# Altium schematic sheet I_PRV_UWS_B2_1_0_PSU.SchDoc
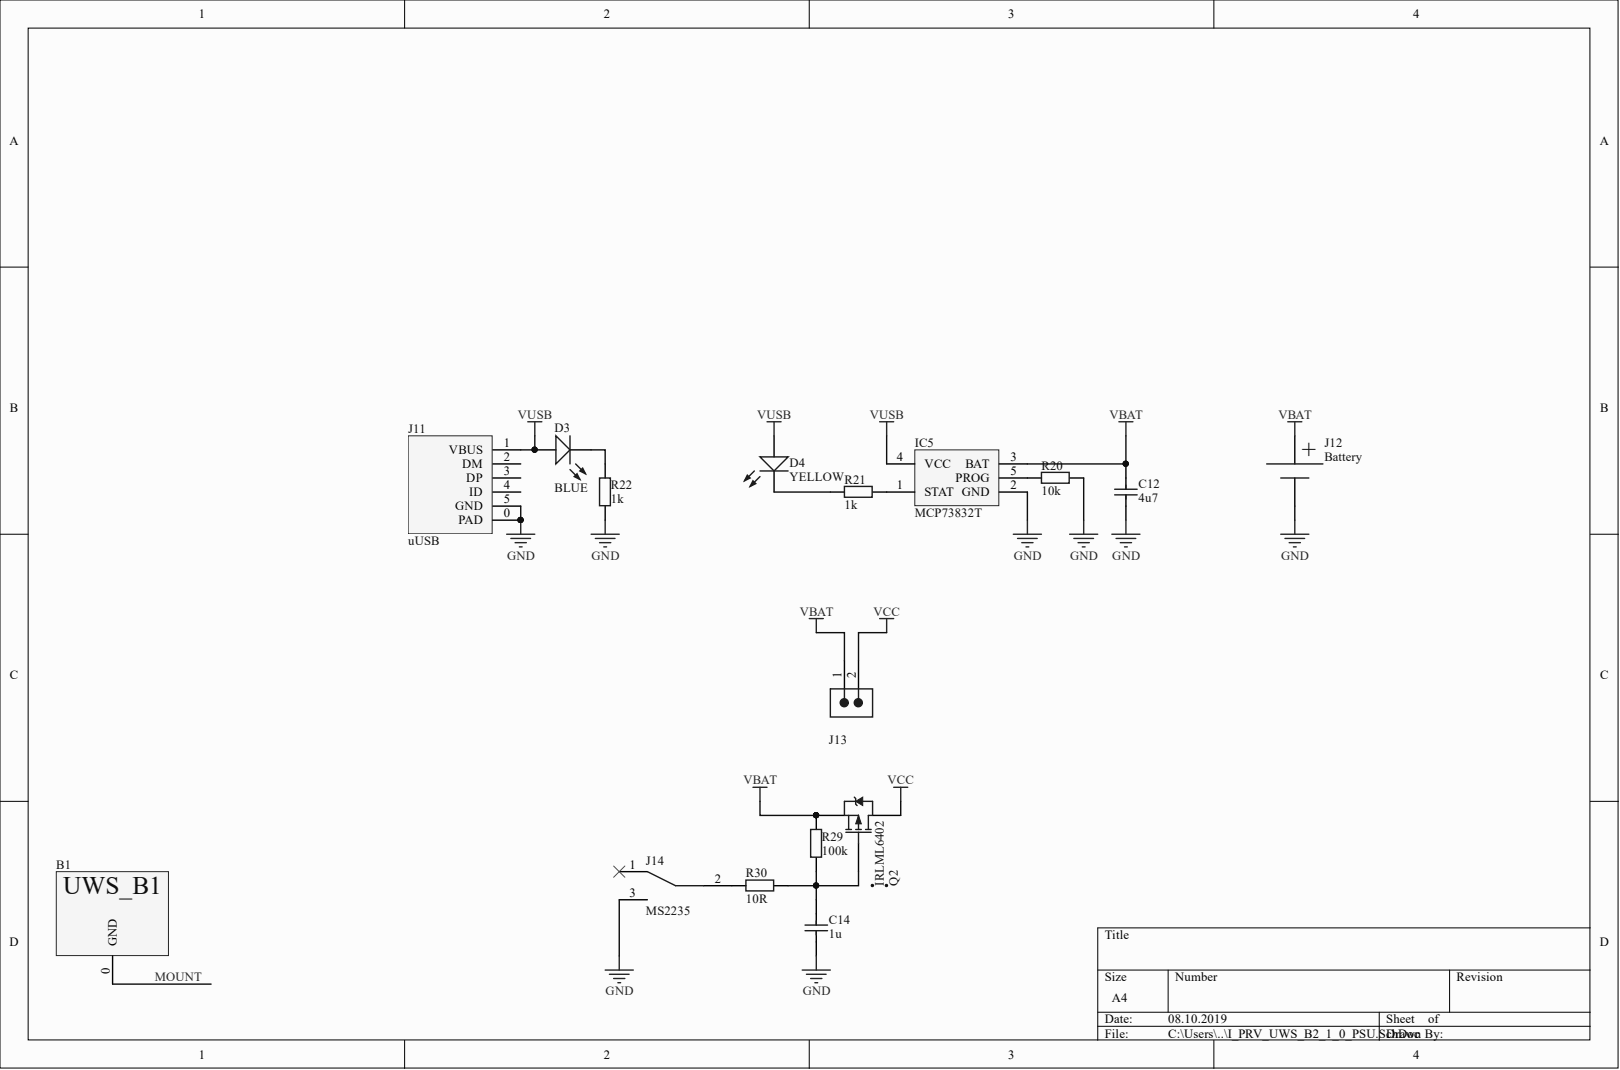
[diagram p0: I_PRV_UWS_B2_1_0_PSU.SchDoc]

## Parts
B1  UWS_B1_outline  [UWS_B1_outline]  (value not drawn)
C12  value 4u7  [SMD0805_CAP]  (hidden comment: Capacitor)
C14  value 1u  [SMD0603_CAP]  (hidden comment: Capacitor)
D3  value BLUE  [SMD0603_LED]  (hidden comment: LED)
D4  value YELLOW  [SMD0603_LED]  (hidden comment: LED)
IC5  MCP73832T  [SOT23-5]
J11  uUSB  [microUSB]
J12  Battery  [LiIon_UWS]
J13  Pinhead 2pin 2.54mm  [Pinhead 2pi 2.54mm]  (value not drawn)
J14  MS2235  [MSS-2235S]
Q2  IRLML6402  [SOT_23]
R20  value 10k  [SMD0402_RES]  (hidden comment: Resistor)
R21  value 1k  [SMD0402_RES]  (hidden comment: Resistor)
R22  value 1k  [SMD0402_RES]  (hidden comment: Resistor)
R29  value 100k  [SMD0402_RES]  (hidden comment: Resistor)
R30  value 10R  [SMD0402_RES]  (hidden comment: Resistor)

## Nets
GND: C12.1 C14.1 IC5.2(GND) J11.0(PAD) J11.5(GND) J12.1 J14.3 R20.2 R22.1
VBAT: C12.2 IC5.3(BAT) J12.2 J13.1 Q2.2 R29.2
VCC: J13.2 Q2.3
VUSB: D3.2 D4.2 IC5.4(VCC) J11.1(VBUS)
MOUNT: B1.0
NetC14_2: C14.2 Q2.1 R29.1 R30.2
NetD3_1: D3.1 R22.2
NetD4_1: D4.1 R21.1
NetIC5_1: IC5.1(STAT) R21.2
NetIC5_5: IC5.5(PROG) R20.1
NetJ14_2: J14.2 R30.1

Not connected: J11 2(DM) 3(DP) 4(ID); J14 1

## Library data of the parts
IC5 = MCP73832T: MCP73832T bat charger; Component Kind: Standard; Library Name: BM_UWS.SchLib
J11 = MicroUSB: Component Kind: Standard; Library Name: BM_UWS.SchLib
J12 = BAT: Component Kind: Standard; Library Name: BM_UWS.SchLib
Q2 = IRLML6402: HEXFET Power P-Channel MOSFET; Component Kind: Standard; LCSC Part #: C15127; Library Name: TCH_SCH_LIB.SchLib
B1 = UWS_B1_outline: Component Kind: Standard; Library Name: BM_UWS.SchLib
C12 C14 = Capacitor: Component Kind: Standard; Description: Capacitor; LCSC Part #: C1779; Library Name: KD_SCH_LIB.SchLib
D3 D4 = LED: Component Kind: Standard; Description: LED; Library Name: KD_SCH_LIB.SchLib
  D3: LCSC Part #: C72041
  D4: LCSC Part #: C72038
J13 = Pinhead 2pin 2.54mm: Component Kind: Standard; Library Name: BM_UWS.SchLib
J14 = MS2235: Component Kind: Standard; Library Name: BM_UWS.SchLib
R20-R22 R29 R30 = Resistor: Component Kind: Standard; Description: Resistor; Library Name: KD_SCH_LIB.SchLib
  R20: LCSC Part #: C25744
  R21 R22 R29 R30: LCSC Part #: C11702
